# Altium schematic sheet Main_Board_Teensy.SchDoc
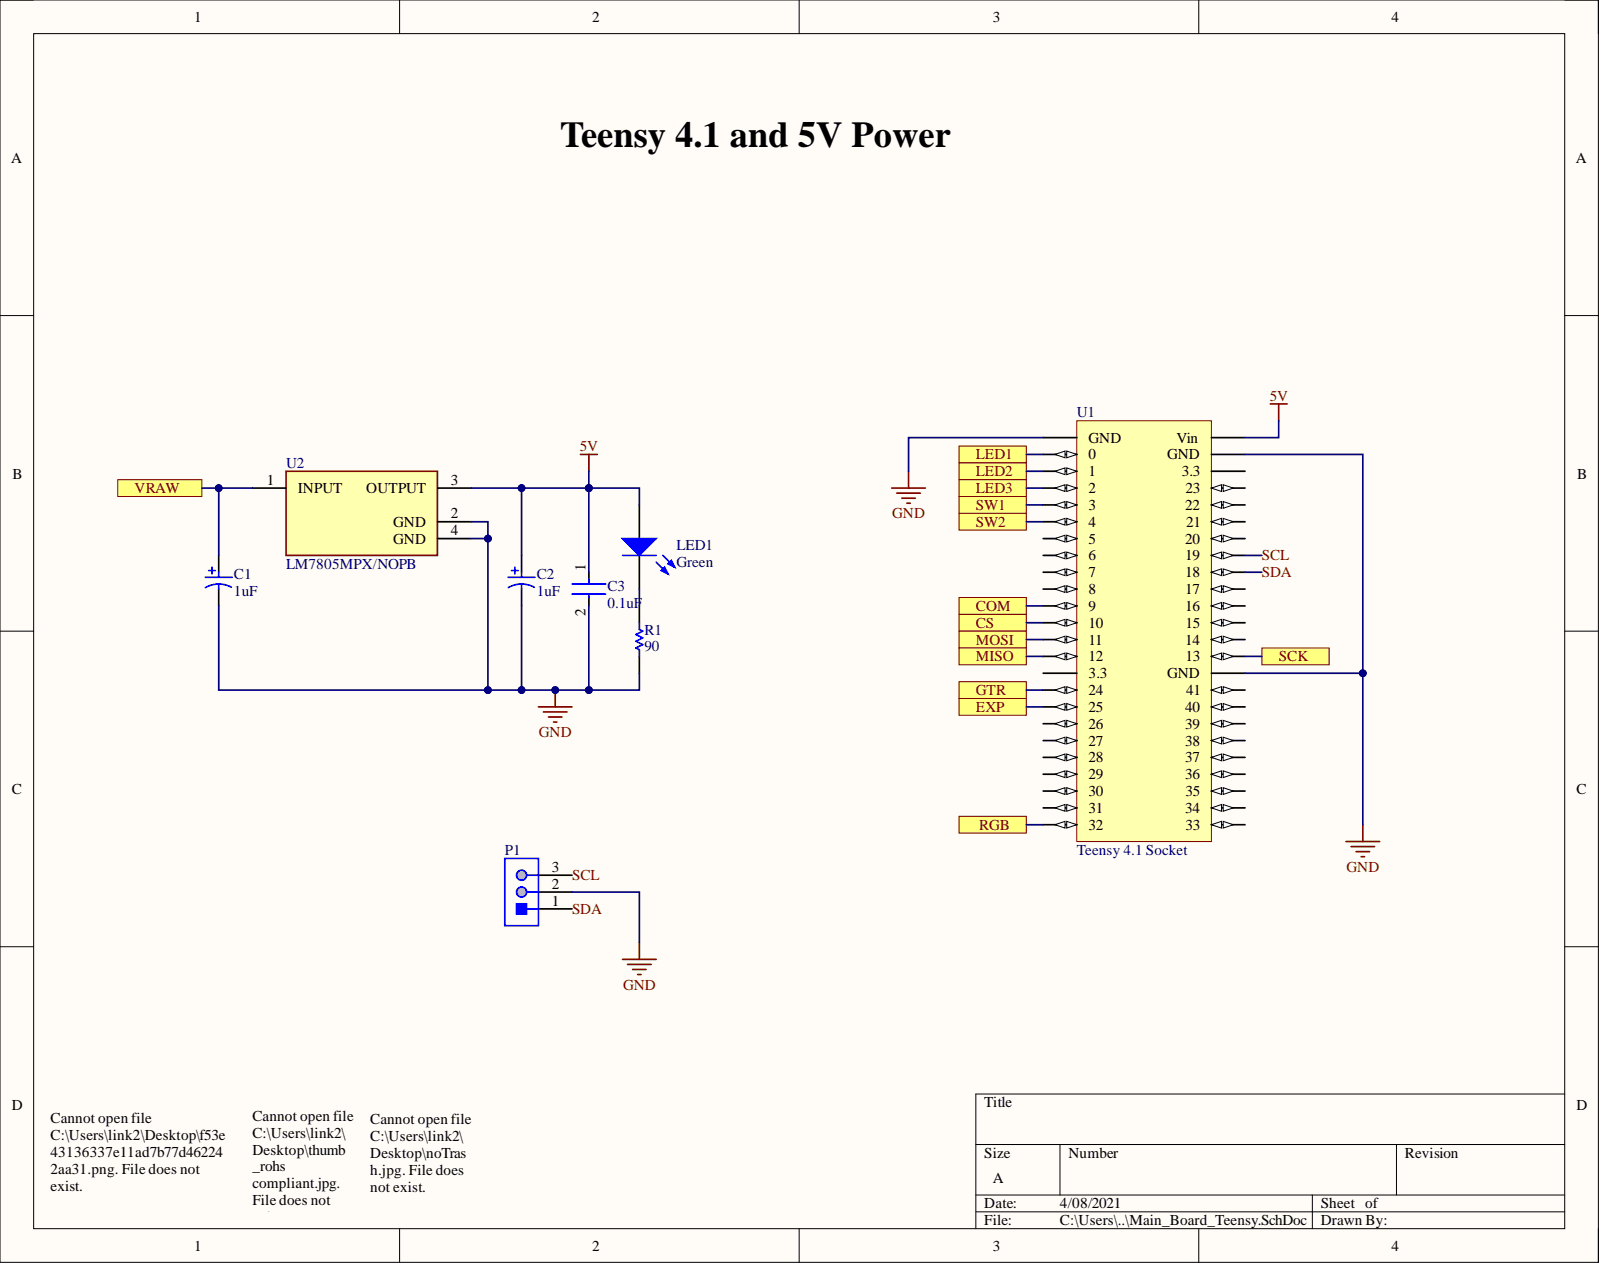
[diagram p0: Main_Board_Teensy.SchDoc]

## Parts
C1  1uF  [KEMT-T491-C_L]
C2  1uF  [KEMT-T491-C_L]
C3  0.1uF  [FP-GCM188-0_1-IPC_B]
LED1  Green  [0603_A]
P1  B3B-PH-K-S(LF)(SN)  [JST-B3B-PH-K-S-3_V]  (value not drawn)
R1  90  [RESC2013X50X40LL20T20]
U1  Teensy 4.1 Socket  [Teensy 4.1 Socket]
U2  LM7805MPX/NOPB  [FP-LM7805MPX-NOPB-MFG]

## Ports
COM, CS, EXP, GTR, LED1, LED2, LED3, MISO, MOSI, RGB, SCK, SW1, SW2, VRAW

## Nets
5V: C2.1 C3.1 LED1.2 U1.Vin U2.3(OUTPUT)
GND: C1.2 C2.2 C3.2 P1.2 R1.1 U1.GND1(GND) U1.GND2(GND) U1.GND3(GND) U2.2(GND) U2.4(GND)
COM: U1.9 ; port COM
CS: U1.10 ; port CS
EXP: U1.25 ; port EXP
GTR: U1.24 ; port GTR
LED1: U1.0 ; port LED1
LED2: U1.1 ; port LED2
LED3: U1.2 ; port LED3
MISO: U1.12 ; port MISO
MOSI: U1.11 ; port MOSI
RGB: U1.32 ; port RGB
SCK: U1.13 ; port SCK
SCL: P1.3 U1.19
SDA: P1.1 U1.18
SW1: U1.3 ; port SW1
SW2: U1.4 ; port SW2
VRAW: C1.1 U2.1(INPUT) ; port VRAW
NetLED1_1: LED1.1 R1.2

Not connected: U1 3.3-1(3.3) 3.3-2(3.3) 5 6 7 8 14 15 16 17 20 21 22 23 26 27 28 29 30 31 33 34 35 36 37 38 39 40 41

## Library data of the parts
C1 C2 = CMP-2000-07195-1: Tantalum Capacitor, 1 uF, +/- 10%, 50 V, -55 to 125 degC, 2-Pin SMD, RoHS, Tape and Reel; Manufacturer: Kemet; Capacitance: 1000000pF; Case Style: Molded; DCL: 0.5uA; DF: 4%; ESR: 5.5ohm; Insulation: NotRequired; Lead Spacing (nom): NotRequiredmm; Polarity: Polar; Product Depth (mm): 3.2mm; Product Height (mm): 2.5mm; Product Length (mm): 6mm; Rad Hardened: No; Voltage: 50VDC; Case Code: C; Component Kind: Standard; Construction: SMTChip; Datasheet URL: http://capacitoredge.kemet.com/capedge2/DataSheet/Datasheet-T491C105K050AT.pdf?pn=T491C105K050AT; Datasheet Version: 10/2016; Failure Rate: NotRequired; Length W/Weld: NotRequiredmm; Length W/Weld (max): NotRequiredmm; Library Name: Altium Content Vault; Manufacturer URL: http://www.kemet.com/; Mounting Style: SurfaceMount; Mounting Technology: SurfaceMount; Operating Temp Range: -55Cto125C; Package / Case: 6032-28; Package Description: 2-Pin Surface Mount Device, Body 6 x 3.2 mm; Package Reference: T491-C; Packaging: TapeandReel; Product Diameter (mm): NotRequiredmm; Seal: NotRequired; Seated Plane Height: NotRequiredmm; Tolerance (+ or -): 10%; Wire Form: NotRequired
C3 = CMP-2006-02353-3: Ceramic Capacitor for Automotive 100nF  ±10% 50VDC X8L 0603 Paper T/R; Voltage: 50V; Capacitance: 100nF; Case Code (Imperial): 0603; Case Code (Metric): 1608; Case/Package: 0603; Component Kind: Standard; Depth: 0.8mm; Dielectric: X8L; Height: 0.8mm; Lead Free: Lead Free; Length: 1.6mm; Library Name: Altium Content Vault; Max Operating Temperature: 150°C; Min Operating Temperature: -55°C; Mount: Surface Mount; Package Quantity: 4000; Packaging: Tape and Reel; Radiation Hardening: No; Ratings: AEC-Q200; Termination: SMD/SMT; Thickness: 0.035inch; Tolerance: 10%; Voltage Rating: 50V; Voltage Rating (DC): 50V; Width: 0.5mm
LED1 = CMP-1426-00002-1: SMD mono-color Chip LED, WL-SMCW, Green; Manufacturer: Wuerth Elektronik; PartNumber: 150060GS75000; Color: Green; Component Kind: Standard; ComponentLink1Description: Manufacturer URL; ComponentLink1URL: http://www.we-online.com; ComponentLink2Description: Datasheet; ComponentLink2URL: http://katalog.we-online.com/led/datasheet/150060GS75000.pdf; Library Name: Altium Content Vault; PackageReference: 0603_A
P1 = CMP-2000-05164-1: Male Header, Pitch 2 mm, 1 x 3 Position, Height 6 mm, Tail Length 3.4 mm, -25 to 85 degC, RoHS, Bulk; Manufacturer: JST; No of Positions: 3; Pitch: 2mm; Style/Orientation: StraightHeader/Plug; Component Kind: Standard; Datasheet URL: https://datasheet.ciiva.com/11746/0900766b80826550-11746939.pdf; Datasheet Version: Oct-06; Library Name: Altium Content Vault; Manufacturer URL: http://www.jst.com/home2.html; Termination: Crimp; Type: ShroudedHeader
R1 = CMP-2001-03378-1: Manufacturer: Susumu; Part Number: RR1220P-331-D; Component Kind: Standard; Library Name: Altium Content Vault
U1 = Teensy 4.1 Socket: Teensy 4.1; Component Kind: Standard; Library Name: SDP21_Main_Board_Schematic_Library.SchLib
U2 = CMP-04917-000022-1: IC REG LINEAR 5V 1.5A SOT223-4; Manufacturer: Texas Instruments; Accuracy Percentage: 3%; Dropout Voltage: 2V; Max Junction Temp: +150°C; Max Supply Voltage: 30V; Min Supply Voltage: 7.5V; Nominal Supply Current: 8mA; Number Of Outputs: 1; Output Type: Fixed; Standoff Height: 0.02mm; Automotive: No; Category: IC; Component Kind: Standard; ComponentLink2Description: Datasheet; ComponentLink2URL: http://www.ti.com/lit/ds/symlink/lm7800.pdf; Device Class L1: Integrated Circuits (ICs); Device Class L2: Power Management ICs; Device Class L3: Voltage Regulators - Linear; Digikey Description: IC REG LINEAR 5V 1.5A SOT223-4; Height: 1.8mm; Lead Free: Yes; Library Name: Altium Content Vault; Manufacturer Part Number: LM7805MPX/NOPB; Octopart: https://octopart.com/lm7805mpx%2Fnopb-texas+instruments-74629992; Output Current: 1.5A; Output Voltage: 5V; Package: SOT223-4; RoHS: TRUE; Temperature: 0°C to +125°C; Temperature Range High: +125°C; Temperature Range Low: 0°C
